# Altium schematic sheet V853_LCD_MIPI_DSI.SchDoc(V853_LCD_MIPI_DSI)
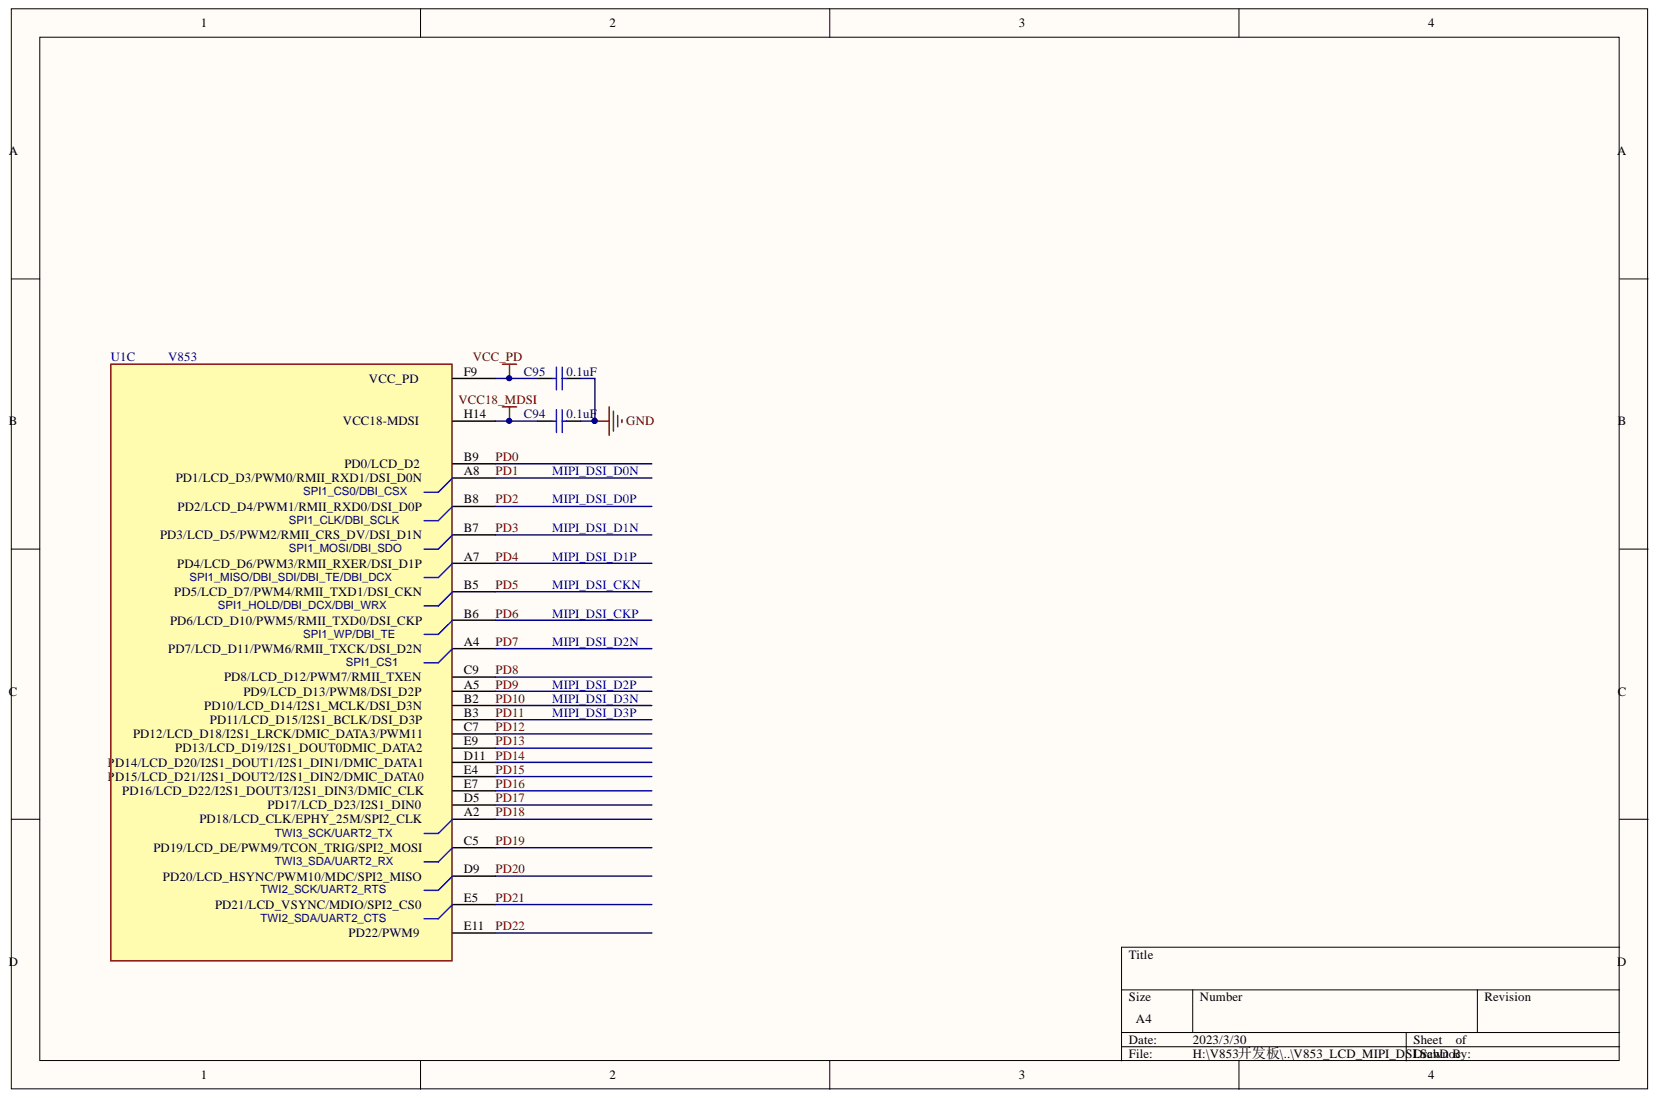
[diagram p0: V853_LCD_MIPI_DSI.SchDoc]

## Parts
C94  0.1uF  [C0201]
C95  0.1uF  [C0201]
U1  V853  (part C)

## Nets
GND: C94.1 C95.1
VCC18_MDSI: C94.2 U1.H14
VCC_PD: C95.2 U1.F9
MIPI_DSI_CKN: U1.B5
MIPI_DSI_CKP: U1.B6
MIPI_DSI_D0N: U1.A8
MIPI_DSI_D0P: U1.B8
MIPI_DSI_D1N: U1.B7
MIPI_DSI_D1P: U1.A7
MIPI_DSI_D2N: U1.A4
MIPI_DSI_D2P: U1.A5
MIPI_DSI_D3N: U1.B2
MIPI_DSI_D3P: U1.B3
PD0: U1.B9
PD8: U1.C9
PD12: U1.C7
PD13: U1.E9
PD14: U1.D11
PD15: U1.E4
PD16: U1.E7
PD17: U1.D5
PD18: U1.A2
PD19: U1.C5
PD20: U1.D9
PD21: U1.E5
PD22: U1.E11

## Library data of the parts
C94 C95 = Cap: Capacitor; PartNumber: CAP100NF; Component Kind: Standard; LatestRevisionDate: 17-Jul-2002; LatestRevisionNote: Re-released for DXP Platform.; Library Name: Miscellaneous Devices.IntLib; PackageReference: 0402; Published: 8-Jun-2000; Signal Integrity: Cap; Simulation: CAP; Value: 0.1uF; Publisher: Altium Limited
U1 = V853_0621: PCB Footprint: BGA318P50B1200_1200H113; SPLIT_INST: TRUE; SWAP_INFO: (S1+S2+S3+S4+S5+S6+S7+S8+S9); Component Kind: Standard; Library Name: V853_CORE_20230224.SCHLIB
